# Altium schematic sheet DCTCXO.SchDoc
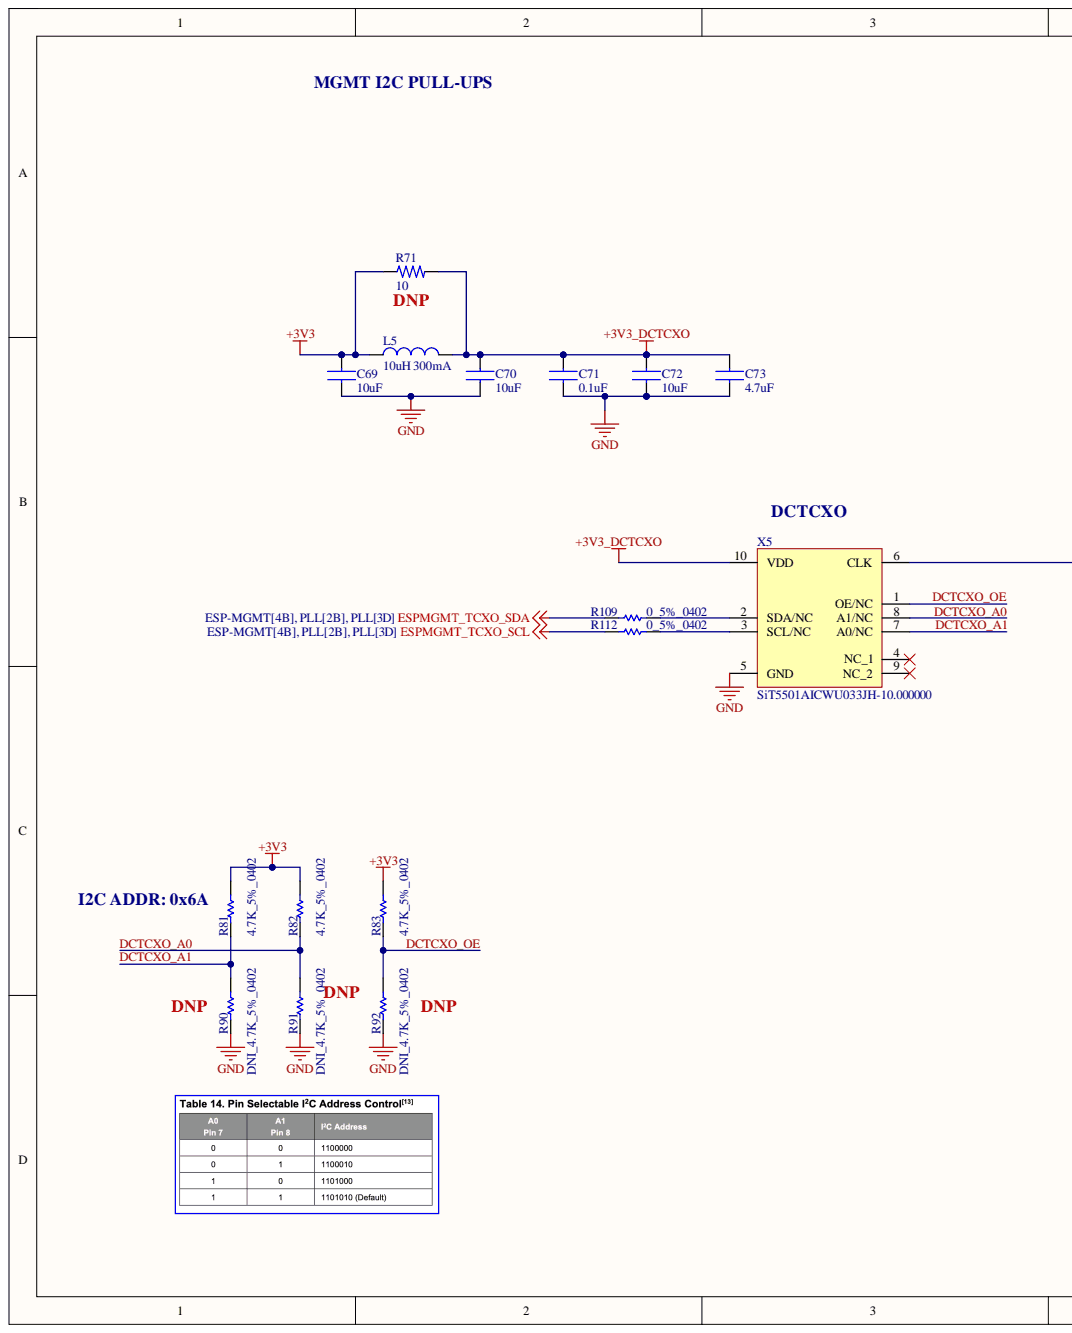
[diagram p0: DCTCXO.SchDoc, left]
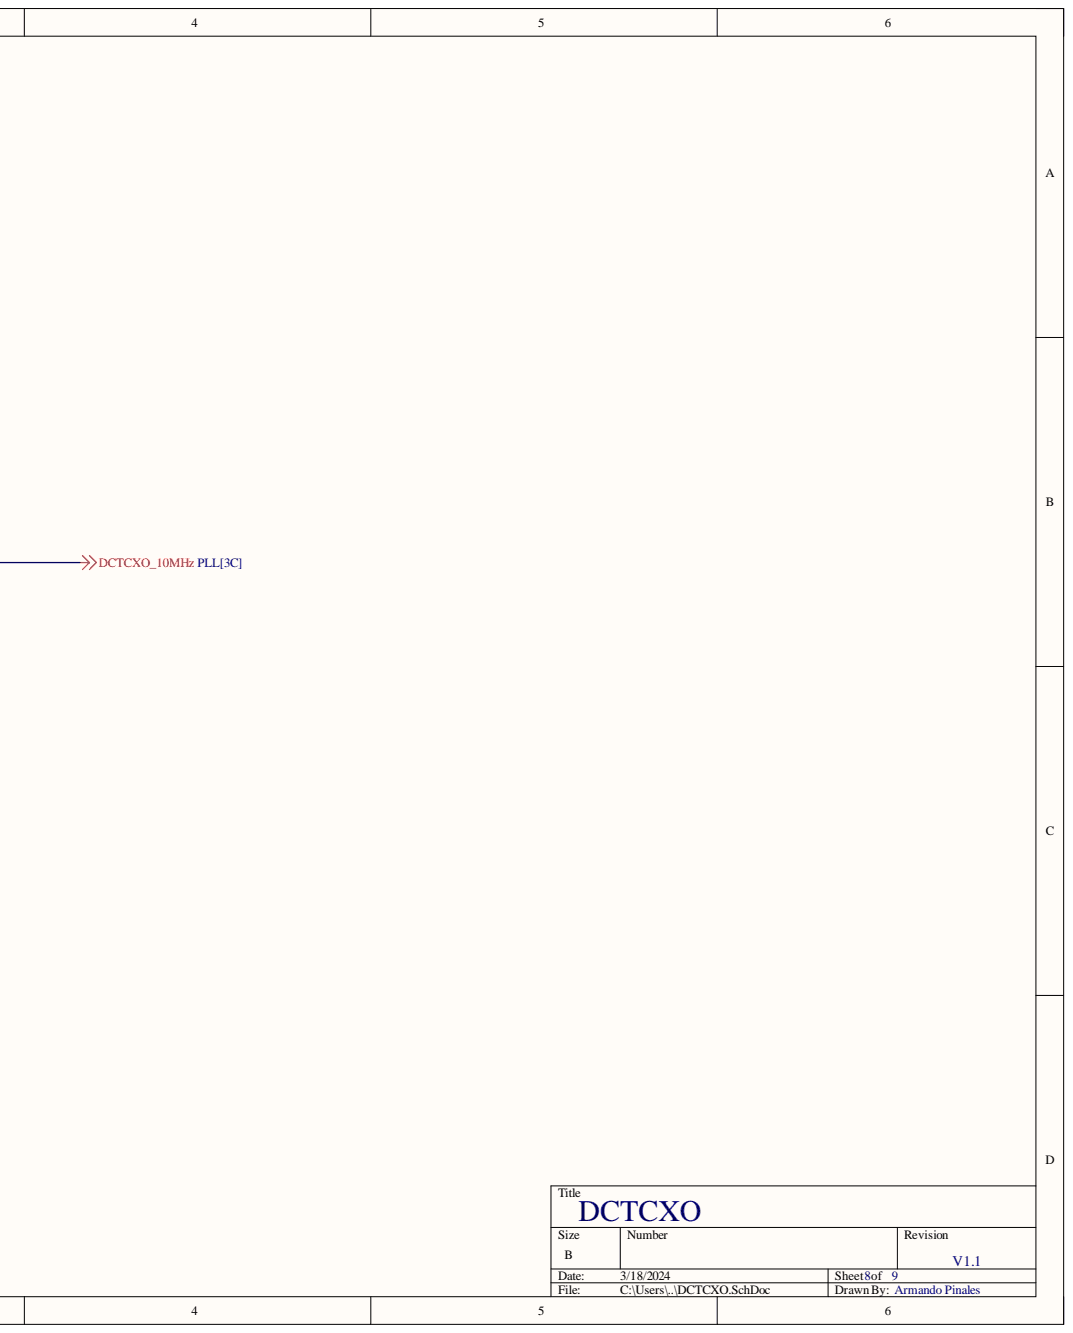
[diagram p1: DCTCXO.SchDoc, right]

## Parts
C69  10uF  [CAP_0402]
C70  10uF  [CAP_0402]
C71  0.1uF  [CAP_0402]
C72  10uF  [CAP_0402]
C73  4.7uF  [CAP_0402]
L5  10uH 300mA  [L_490-LQM18DN100M70LCT-ND]
R71  10  [RES_0402]  (no BOM)
R81  4.7K_5%_0402  [RESC1005X40X25LL05T05]
R82  4.7K_5%_0402  [RESC1005X40X25LL05T05]
R83  4.7K_5%_0402  [RESC1005X40X25LL05T05]
R90  DNI_4.7K_5%_0402  [RESC1005X40X25LL05T05]  (no BOM)
R91  DNI_4.7K_5%_0402  [RESC1005X40X25LL05T05]  (no BOM)
R92  DNI_4.7K_5%_0402  [RESC1005X40X25LL05T05]  (no BOM)
R109  0_5%_0402  [RESC1005X40X25ML05T10]
R112  0_5%_0402  [RESC1005X40X25ML05T10]
X5  SiT5501AICWU033JH-10.000000  [XTAL_SiT5501_70x50]

## Nets
+3V3: C69.2 L5.1 R71.1 R81.2 R82.2 R83.2
+3V3_DCTCXO: C70.2 C71.2 C72.2 C73.2 L5.2 R71.2 X5.10(VDD)
DCTCXO_10MHz: X5.6(CLK)
ESPMGMT_TCXO_SCL: R112.1
ESPMGMT_TCXO_SDA: R109.1
GND: C69.1 C70.1 C71.1 C72.1 C73.1 R90.1 R91.1 R92.1 X5.5(GND)
DCTCXO_A0: R82.1 R91.2 X5.8(A1/NC)
DCTCXO_A1: R81.1 R90.2 X5.7(A0/NC)
DCTCXO_OE: R83.1 R92.2 X5.1(OE/NC)
NetR109_2: R109.2 X5.2(SDA/NC)
NetR112_2: R112.2 X5.3(SCL/NC)

Not connected: X5 4(NC_1) 9(NC_2)

## Library data of the parts
C69 C70 C72 = CAP_CL05A106MQ5NUNC: Cap Ceramic 10uF 6.3V X5R 20% SMD 0402 85°C Paper T/R; Manufacturer: Samsung; Capacitance: 10uF; Case Code (Imperial): 0402; Case Code (Metric): 1005; Case/Package: 0402; Category: Ceramic Capacitors; Component Kind: Standard; ComponentLink1Description: https://datasheet.ciiva.com/16437/cl05a106mq5nunc-16437356.pdf?src-supplier=Future+Electronics; ComponentLink1URL: https://datasheet.ciiva.com/16437/cl05a106mq5nunc-16437356.pdf?src-supplier=Future+Electronics; ComponentLink2Description: https://datasheet.ciiva.com/26877/getdatasheetpartid-355289-26877841.pdf?src-supplier=Verical; ComponentLink2URL: https://datasheet.ciiva.com/26877/getdatasheetpartid-355289-26877841.pdf?src-supplier=Verical; Construction: Flat; Depth: 0.5mm; Dielectric: X5R; Height: 0.5mm; Length: 1mm; Manufacturer Part Number: CL05A106MQ5NUNC; Max Operating Temperature: 85°C; Min Operating Temperature: -55°C; Mount: Surface Mount; Package Quantity: 1; Packaging: Tape and Reel; Pricing 1: 1=0.27000, 10=0.18400, 50=0.11020, 100=0.09300, 500=0.06362, 1000=0.05629, 2500=0.05384, 5000=0.04894 (USD); Radiation Hardening: No; RoHS: Yes; Series: CL; Stock 1: 3345553; Supplier 1: Digi-Key; Supplier Part Number 1: 1276-1451-1-ND; Thickness: 0.028inch; Tolerance: 20%; Voltage Rating: 6.3V; Width: 0.02inch; Library Name: LotusSound.IntLib
C71 = CAP_GCM155R71C104KA55J: Cap Ceramic 0.1uF 16V X7R 10% SMD 0402 125C Paper T/R; Manufacturer: Murata; Capacitance: 100nF; Case Code (Imperial): 0402; Case Code (Metric): 1005; Case/Package: 0402; Category: Ceramic Capacitors; Component Kind: Standard; ComponentLink1Description: https://datasheet.ciiva.com/11618/product-11618848.pdf?src-supplier=Avnet; ComponentLink1URL: https://datasheet.ciiva.com/11618/product-11618848.pdf?src-supplier=Avnet; ComponentLink2Description: https://datasheet.ciiva.com/16440/gcm155r71c104ka55j-16440393.pdf?src-supplier=Future+Electronics; ComponentLink2URL: https://datasheet.ciiva.com/16440/gcm155r71c104ka55j-16440393.pdf?src-supplier=Future+Electronics; ComponentLink3Description: https://datasheet.ciiva.com/29542/product-29542474.pdf?src-supplier=Digi-Key; ComponentLink3URL: https://datasheet.ciiva.com/29542/product-29542474.pdf?src-supplier=Digi-Key; ComponentLink4Description: https://datasheet.ciiva.com/29542/product-29542475.pdf?src-supplier=Digi-Key; ComponentLink4URL: https://datasheet.ciiva.com/29542/product-29542475.pdf?src-supplier=Digi-Key; Construction: Flat; Depth: 0.5mm; Dielectric: X7R; Height: 0.5mm; Lead Free: Lead Free; Length: 1mm; Manufacturer Part Number: GCM155R71C104KA55J; Max Operating Temperature: 125°C; Min Operating Temperature: -55°C; Mount: Surface Mount; Package Quantity: 1; Packaging: Tape and Reel; Pricing 1: 1=0.10000, 10=0.03500, 50=0.01920, 100=0.01560, 500=0.01116, 1000=0.00877, 2500=0.00798, 5000=0.00734 (USD); Radiation Hardening: No; Ratings: AEC-Q200; RoHS: Yes; Series: GCM; Stock 1: 10738061; Supplier 1: Digi-Key; Supplier Part Number 1: 490-7998-1-ND; Termination: SMD/SMT; Thickness: 0.022inch; Tolerance: 10%; Voltage Rating: 16V; Voltage Rating (DC): 16V; Width: 0.5mm; Library Name: LotusSound.IntLib
C73 = CAP_LMK105BBJ475MVLF: Multilayer Ceramic Capacitors MLCC - SMD/SMT 4.7uF 10V X5R +/-20% 0402 Gen Purp; Manufacturer: Taiyo Yuden; Tolerance: 20%; Capacitance: 4.7uF; Case Code (Imperial): 0402; Case Code (Metric): 1005; Case/Package: 0402; Category: Ceramic Capacitors; Component Kind: Standard; ComponentLink1Description: https://datasheet.ciiva.com/24462/423lmk105bbj475mvlf-24462535.pdf?src-supplier=Onlinecomponents.com; ComponentLink1URL: https://datasheet.ciiva.com/24462/423lmk105bbj475mvlf-24462535.pdf?src-supplier=Onlinecomponents.com; ComponentLink2Description: https://datasheet.ciiva.com/18697/mlcc-all-e-18697686.pdf?src-supplier=Digi-Key; ComponentLink2URL: https://datasheet.ciiva.com/18697/mlcc-all-e-18697686.pdf?src-supplier=Digi-Key; ComponentLink3Description: https://datasheet.ciiva.com/18697/mlcc-all-e-18697685.pdf?src-supplier=Digi-Key; ComponentLink3URL: https://datasheet.ciiva.com/18697/mlcc-all-e-18697685.pdf?src-supplier=Digi-Key; Dielectric: X5R; Halogen Free: Halogen Free; Height: 0.5mm; Length: 1mm; Manufacturer Part Number: LMK105BBJ475MVLF; Max Operating Temperature: 85°C; Min Operating Temperature: -55°C; Mount: Surface Mount; Package Quantity: 10000; Packaging: Tape and Reel; Pricing 1: 1=0.21000, 10=0.14400, 50=0.08640, 100=0.07300, 500=0.04994, 1000=0.04417, 2500=0.04225, 5000=0.03841 (USD); Resistor Type: Ceramic; RoHS: Yes; RoHS Compliant: Yes; Supplier 1: Digi-Key; Supplier Part Number 1: 587-3408-1-ND; Termination: SMD/SMT; Thickness: 0.022inch; Voltage Rating: 10V; Voltage Rating (DC): 10V; Weight: 2.3E-05oz; Width: 0.02inch; Library Name: LotusSound.IntLib
L5 = L_LQM18DN100M70L: Inductor, 10Uh, 0.3A, Multilayer Rohs Compliant: Yes; Manufacturer: Murata; Category: Fixed Inductors; Component Kind: Standard; Manufacturer Part Number: LQM18DN100M70L; Pricing 1: 1=0.12000, 10=0.10500, 25=0.09280, 50=0.07900, 100=0.06970, 250=0.06388, 500=0.05808, 1000=0.04878 (USD); RoHS: Yes; Stock 1: 236207; Supplier 1: Digi-Key; Supplier Part Number 1: 490-LQM18DN100M70LCT-ND; Library Name: LotusSound.IntLib
R71 = RES_RC0402FR-0710RL: Res General Purpose Thick Film 0402 10 Ohm 1% 1/16W ±200ppm/°C Molded SMD Paper T/R; Manufacturer: Yageo; Max Power Dissipation: 63mW; Power Rating: 62.5mW; Value: 10R; Voltage Rating: 50V; Case Code (Imperial): 0402; Case Code (Metric): 1005; Case/Package: 0402; Category: Chip SMD Resistors; ComponentLink1Description: https://datasheet.ciiva.com/46394/1018594-46394954.pdf?src-supplier=Element14; ComponentLink1URL: https://datasheet.ciiva.com/46394/1018594-46394954.pdf?src-supplier=Element14; ComponentLink2Description: https://datasheet.ciiva.com/7849/1018594-7849818.pdf?src-supplier=Element14; ComponentLink2URL: https://datasheet.ciiva.com/7849/1018594-7849818.pdf?src-supplier=Element14; ComponentLink3Description: https://datasheet.ciiva.com/7849/1018594-7849819.pdf?src-supplier=Element14; ComponentLink3URL: https://datasheet.ciiva.com/7849/1018594-7849819.pdf?src-supplier=Element14; ComponentLink4Description: https://datasheet.ciiva.com/15189/getdatasheetpartid-323697-15189339.pdf?src-supplier=Verical; ComponentLink4URL: https://datasheet.ciiva.com/15189/getdatasheetpartid-323697-15189339.pdf?src-supplier=Verical; Construction: Rectangular; Depth: 0.5mm; Features: Moisture Resistant; Height: 0.32mm; Lead Free: Lead Free; Length: 1mm; Manufacturer Part Number: RC0402FR-0710RL; Max Operating Temperature: 155°C; Military Standard: Not; Min Operating Temperature: -55°C; Number of Terminations: 2; Packaging: Tape and Reel; Pricing 1: 1=0.10000, 10=0.02200, 100=0.00880, 1000=0.00396, 2500=0.00344, 5000=0.00284 (USD); Reach SVHC Compliant: Yes; Resistance Tolerance: 1%; Resistor Type: Thick Film; RoHS: Yes; RoHS Compliant: Yes; Series: RC; Stock 1: 6576941; Supplier 1: Digi-Key; Supplier Part Number 1: 311-10.0LRCT-ND; Technology: THICK FILM; Temperature Coefficient: 200ppm/°C; Termination: SMD/SMT; Tolerance: 1%; Working Voltage: 50V; Library Name: LotusSound.IntLib
R81-R83 R90-R92 = CMP-1011-00090-2: Manufacturer: Vishay Dale; Part Number: CRCW04024K70JNTD; Library Name: Altium Content Vault
  R81-R83: Component Kind: Standard
R109 R112 = CMP-2002-06457-1: Manufacturer: Panasonic; Part Number: ERJ-U020R00X; Component Kind: Standard; Library Name: Altium Content Vault
X5 = XTAL_SIT5501AI-WUG33JT-10.000000: 1 MHz  60 MHz, Elite X Stratum 3E Precision Oscillator; Manufacturer_Name: SiTime; Manufacturer_Part_Number: SiT5501AI-WU033JH-10.000000; Geometry.Height: 2.2mm; Component Kind: Standard; Datasheet Link: https://www.sitime.com/support/resource-library/datasheets/sit5501-datasheet; Library Name: LotusSound.IntLib
